# Altium schematic sheet Sheet1.SchDoc(Sheet1)
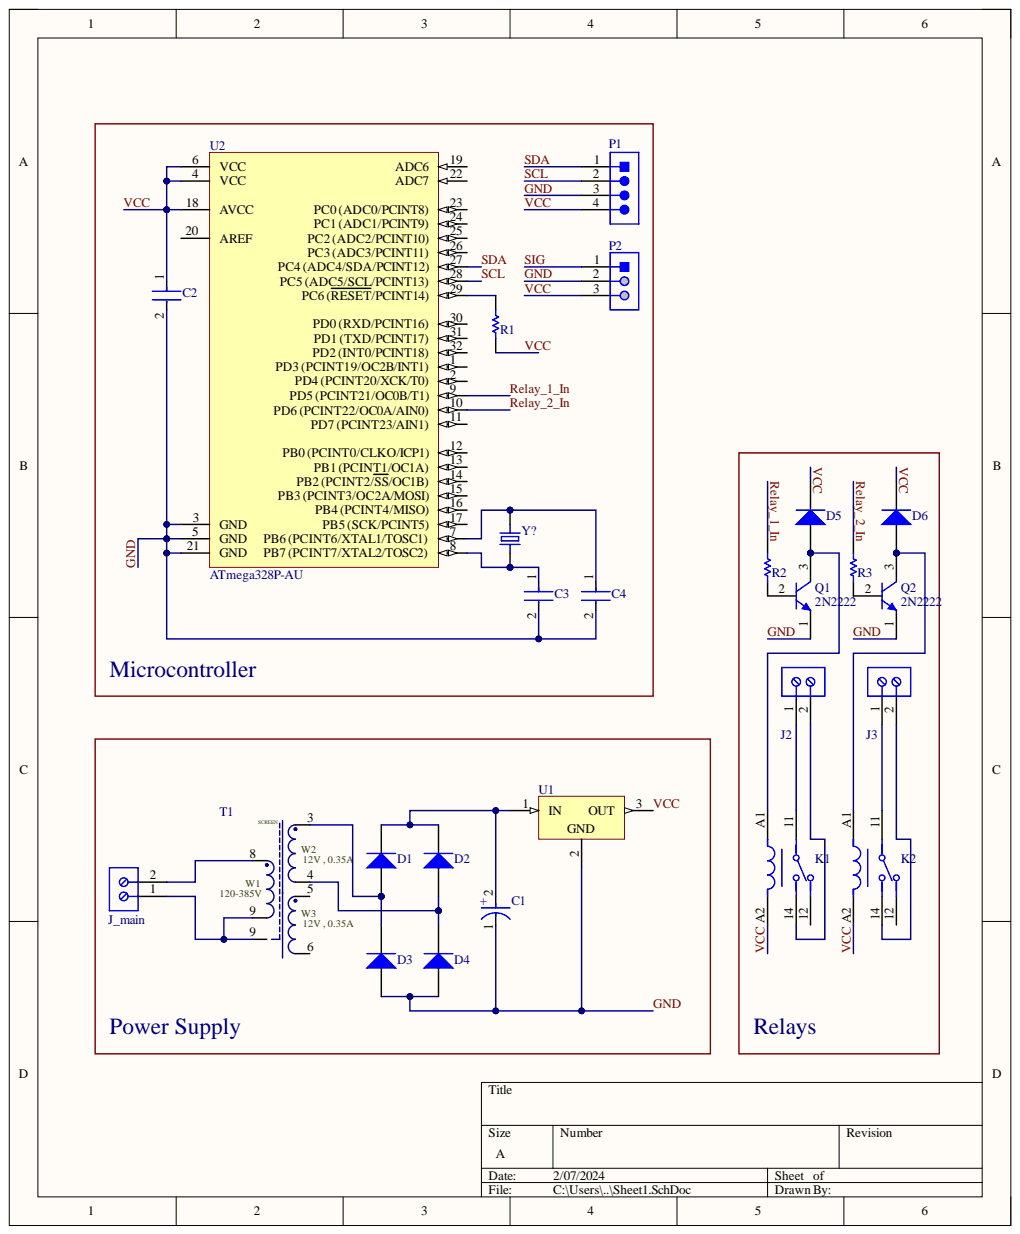
[diagram p0: Sheet1.SchDoc]

## Parts
C1  EEE-FK1E102AQ  [FP-FKH13-MFG]  (value not drawn)
C2  GRM188R60J106ME47D  [FP-GRM188-0_1-e0_2_0_55-IPC_A]  (value not drawn)
C3  RCE5C1H220J0M1H03A  [FP-RCE0K1-MFG]  (value not drawn)
C4  RCE5C1H220J0M1H03A  [FP-RCE0K1-MFG]  (value not drawn)
D1  1N4148W-7-F  [DIOD-SOD123-2_V]  (value not drawn)
D2  1N4148W-7-F  [DIOD-SOD123-2_V]  (value not drawn)
D3  1N4148W-7-F  [DIOD-SOD123-2_V]  (value not drawn)
D4  1N4148W-7-F  [DIOD-SOD123-2_V]  (value not drawn)
D5  1N4148W-7-F  [DIOD-SOD123-2_V]  (value not drawn)
D6  1N4148W-7-F  [DIOD-SOD123-2_V]  (value not drawn)
J2  1714955  [PHOE-1714955_V]  (value not drawn)
J3  1714955  [PHOE-1714955_V]  (value not drawn)
J_main  1714955  [PHOE-1714955_V]  (value not drawn)
K1  1393236-1  [FP-1393236-1-MFG]  (value not drawn)
K2  1393236-1  [FP-1393236-1-MFG]  (value not drawn)
P1  B4B-PH-K-S(LF)(SN)  [JST-B4B-PH-K-S_V]  (value not drawn)
P2  B3B-PH-K-S(LF)(SN)  [JST-B3B-PH-K-S-3_V]  (value not drawn)
Q1  2N2222  [MLTC-TO-18-3]
Q2  2N2222  [MLTC-TO-18-3]
R1  ERJ8BWFR015V  [RESC3216X70X50NL05T20]  (value not drawn)
R2  ERJ8BWFR015V  [RESC3216X70X50NL05T20]  (value not drawn)
R3  ERJ8BWFR015V  [RESC3216X70X50NL05T20]  (value not drawn)
T1  7491182012  [EE16_7_4 (E16)]  (value not drawn)
U1  LM7805CT  [FAIR-TO-220-3]  (value not drawn)
U2  ATmega328P-AU  [32A_M]
Y?  ECS-160-S-1X  [XTAL_ECS-160-S-1X]  (value not drawn)

## Nets
GND: C1.1 C2.2 C3.2 C4.2 D3.1 D4.1 P1.3 P2.2 Q1.1 Q2.1 U1.2 U2.3(GND) U2.5(GND) U2.21(GND)
Relay_1_In: R2.1 U2.9(PD5_(PCINT21/OC0B/T1))
Relay_2_In: R3.1 U2.10(PD6_(PCINT22/OC0A/AIN0))
SCL: P1.2 U2.28(PC5_(ADC5/SCL/PCINT13))
SDA: P1.1 U2.27(PC4_(ADC4/SDA/PCINT12))
SIG: P2.1
VCC: C2.1 D5.2 D6.2 K1.A2 K2.A2 P1.4 P2.3 R1.2 U1.3 U2.4(VCC) U2.6(VCC) U2.18(AVCC)
NetC1_2: C1.2 D1.2 D2.2 U1.1
NetC3_1: C3.1 U2.8 Y?.1
NetC4_1: C4.1 U2.7 Y?.2
NetD1_1: D1.1 D3.2 T1.3
NetD2_1: D2.1 D4.2 T1.4
NetD5_1: D5.1 K1.A1 Q1.3
NetD6_1: D6.1 K2.A1 Q2.3
NetJ2_1: J2.1 K1.11
NetJ2_2: J2.2 K1.14
NetJ3_1: J3.1 K2.11
NetJ3_2: J3.2 K2.14
NetJ_main_1: J_main.1 T1.9
NetJ_main_2: J_main.2 T1.8
NetQ1_2: Q1.2 R2.2
NetQ2_2: Q2.2 R3.2
NetR1_1: R1.1 U2.29(PC6_(RESET/PCINT14))

Not connected: K1 12; K2 12; T1 5 6; U2 1(PD3_(PCINT19/OC2B/INT1)) 2(PD4_(PCINT20/XCK/T0)) 11(PD7_(PCINT23/AIN1)) 12(PB0_(PCINT0/CLKO/ICP1)) 13(PB1_(PCINT1/OC1A)) 14(PB2_(PCINT2/SS/OC1B)) 15(PB3_(PCINT3/OC2A/MOSI)) 16(PB4_(PCINT4/MISO)) 17(PB5_(SCK/PCINT5)) 19(ADC6) 20(AREF) 22(ADC7) 23(PC0_(ADC0/PCINT8)) 24(PC1_(ADC1/PCINT9)) 25 26(PC3_(ADC3/PCINT11)) 30(PD0_(RXD/PCINT16)) 31(PD1_(TXD/PCINT17)) 32(PD2_(INT0/PCINT18))

## Library data of the parts
C1 = CMP-05427-002158-1: CAP ALUM 1000UF 20% 25V SMD; Capacitance: 1mF; ESR (Equivalent Series Resistance): 60mR; Life (Hours): 5000Hour; Polarity: Polar; Ripple Current: 1.1A; Case/Package: Radial; Component Kind: Standard; Construction: Cylindrical; Diameter: 12.5mm; Height: 13.5mm; Height - Seated (Max): 0.55inch; Impedance: 60mR; Lead Free: Lead Free; Length: 13.5mm; Library Name: Altium Content Vault; Max Operating Temperature: 105°C; Min Operating Temperature: -55°C; Mount: Surface Mount; Package Quantity: 200; Packaging: Tape and Reel; Radiation Hardening: No; Ratings: AEC-Q200; Reach SVHC Compliant: Yes; Ripple Current (AC): 1.1A; RoHS Compliant: Yes; Series: FK; Termination: SMD/SMT; Tolerance: 20%; Voltage: 25V; Voltage Rating: 25V; Voltage Rating (DC): 25V
C2 = CMP-2006-02792-2: Chip Multilayer Ceramic Capacitors for General Purpose, 0603, 10uF, X5R, 15%, 20%, 6.3V; Capacitance: 10uF; Case Code (Imperial): 0603; Case Code (Metric): 1608; Case/Package: 0603; Component Kind: Standard; Depth: 0.8mm; Dielectric: X5R; Height: 0.8mm; Lead Free: Lead Free; Length: 1.6mm; Library Name: Altium Content Vault; Material: Ceramic; Max Operating Temperature: 85°C; Min Operating Temperature: -55°C; Mount: Surface Mount; Package Quantity: 4000; Packaging: Tape and Reel; Radiation Hardening: No; REACH SVHC: No SVHC; Termination: SMD/SMT; Thickness: 0.035inch; Tolerance: 20%; Voltage: 6.3V; Voltage Rating: 6.3V; Voltage Rating (DC): 6.3V; Width: 0.031inch
C3 C4 = CMP-06035-004895-1: Cap Ceramic 22pF 50V C0G ±5% Radial 5mm +125°C Automotive Bulk; Lead Diameter: 0.5mm; Lead/Base Style: Formed Leads; Capacitance: 22pF; Case/Package: Radial; Component Kind: Standard; Dielectric: C0G; Height: 3.5mm; Height - Seated (Max): 0.138inch; Lead Pitch: 0.197inch; Length: 0.142inch; Library Name: Altium Content Vault; Max Operating Temperature: 125°C; Min Operating Temperature: -55°C; Mount: Through Hole; Package Quantity: 2000; Packaging: Tape and Reel; Ratings: AEC-Q200; Resistor Type: Ceramic; Termination: Radial; Tolerance: 5%; Voltage Rating: 50V; Voltage Rating (DC): 50V; Width: 0.098inch
D1-D6 = CMP-2000-04932-2: Fast Switching Diode, 100 V, 0.15 A, -65 to 150 degC, 2-Pin?SOD123, RoHS, Tape and Reel; Brand: DiodesIncorporated; Configuration: Single; If - Forward Current: 0.15A; Ir - Reverse Current: 1uA; Max Surge Current: 2A; Peak Reverse Voltage: 100V; Recovery Time: 4ns; Unit Weight: 0.000353oz; Vf - Forward Voltage: 1.25V; Component Kind: Standard; Datasheet URL: http://www.farnell.com/datasheets/1915529.pdf; Datasheet Version: Rev. 24-2, 10/2013; Factory Pack Quantity: 3000; Height: 1.25mm; Length: 2.85mm; Library Name: Altium Content Vault; Manufacturer: DiodesIncorporated; Manufacturer URL: http://www.diodes.com/; Maximum Operating Temperature: +150C; Minimum Operating Temperature: -65C; Mounting Style: SMD/SMT; Operating Temperature Range: -65Cto+150C; Package / Case: SOD-123; Package Reference: SOD123-2; Package URL: http://www.diodes.com/datasheets/ds18004.pdf; Packaging: Reel; Product: SwitchingDiodes; Product Category: Diodes-GeneralPurpose,Power,Switching; RoHS: True; Series: 1N4148; Type: SwitchingDiode; Width: 1.7mm
J2 J3 J_main = CMP-2000-05797-1: PC Terminal Block, Pitch 6.35 mm, 1 x 2 Position, Height 21.5 mm, Tail Lenght 5.1 mm, RoHS, Bulk; Manufacturer: Phoenix Contact; Component Kind: Standard; Datasheet URL: http://media.digikey.com/pdf/Data%20Sheets/Phoenix%20Contact%20PDFs/1714955.pdf; Datasheet Version: 02/2010; Library Name: Altium Content Vault; Manufacturer URL: http://www.phoenixcontact.com/; Mounting Technology: ThroughHole; Package Description: PC Terminal Block, Pitch 6.35 mm, 1 x 2 Position, Height 21.5 mm, Tail Lenght 5.1 mm; Package Reference: 1714955
K1 K2 = CMP-03395-000054-1: SNR Series 6 A SPDT (1 Form A) 5 VDC PCB Mount General Purpose Power Relay; Manufacturer: TE; Coil Power: 170mW; Coil Resistance: 147Ω; Coil Type: Non-Latching; Coil Voltage: 5V; Current Rating: 6A; Number Of Contacts: 1; Standoff Height: 0.5mm; Ambient Temperature Range High: +85°C; Ambient Temperature Range Low: -40°C; Automotive: No; Category: Relay; Component Kind: Standard; ComponentLink2Description: Datasheet; ComponentLink2URL: https://www.te.com/usa-en/product-1393236-1.html?te_bu=Cor&te_type=other&te_campaign=oct_glo_cor-oct-global-oth-prtnr-fy19-oct-octo-buy-bom_sma-298_2&elqCampaignId=35216; Device Class L1: Electromechanical; Device Class L2: Relays; Device Class L3: Power Relays; Digikey Description: RELAY GENERAL PURPOSE SPDT 6A 5V; Height: 15mm; Lead Free: Yes; Library Name: Altium Content Vault; Manufacturer Part Number: 1393236-1; Mouser Description: General Purpose Relays RELAY PWR SPDT; Octopart: https://octopart.com/1393236-1-te+connectivity-40317174; Package: 1393236-1; RoHS: TRUE; Throw Configuration: SPDT
P1 = CMP-2000-05165-1: Male Header, Pitch 2 mm, 1 x 4 Position, Height 6 mm, Tail Length 3.4 mm, -25 to 85 degC, RoHS, Bulk; Manufacturer: JST; No of Positions: 4; Pitch: 2mm; Style/Orientation: StraightHeader/Plug; Component Kind: Standard; Datasheet URL: http://datasheet.octopart.com/B4B-PH-K-S%28LF%29%28SN%29-JST-datasheet-10558886.pdf; Datasheet Version: Apr-09; Library Name: Altium Content Vault; Manufacturer URL: http://www.jst.com/home2.html; Termination: Crimp; Type: ShroudedHeader
P2 = CMP-2000-05164-1: Male Header, Pitch 2 mm, 1 x 3 Position, Height 6 mm, Tail Length 3.4 mm, -25 to 85 degC, RoHS, Bulk; Manufacturer: JST; No of Positions: 3; Pitch: 2mm; Style/Orientation: StraightHeader/Plug; Component Kind: Standard; Datasheet URL: https://datasheet.ciiva.com/11746/0900766b80826550-11746939.pdf; Datasheet Version: Oct-06; Library Name: Altium Content Vault; Manufacturer URL: http://www.jst.com/home2.html; Termination: Crimp; Type: ShroudedHeader
Q1 Q2 = CMP-2000-05237-1: Low Power Bipolar NPN Transistor, 30 V, -65 to 200 degC, 3-Pin TO-18, RoHS, Bulk; Manufacturer: Multicomp; Collector Emitter Voltage V(br)ceo: 30V; Collector Emitter Voltage Vces: 400mV; Continuous Collector Current Ic Max: 800mA; Current Ic @ Vce Sat: 150mA; DC Current Gain hFE: 100; Full Power Rating Temperature: 25degC; Gain Bandwidth ft Min: 250MHz; No. of Pins: 3; No. of Transistors: 1; Pin Configuration: a; Power Dissipation Pd: 500mW; SVHC: NoSVHC(19-Dec-2012); Voltage Vcbo: 60V; Component Kind: Standard; Current Ic Continuous a Max: 800mA; Current Ic hFE: 150mA; Datasheet URL: http://www.farnell.com/datasheets/1662272.pdf; Datasheet Version: Rev. 1.1, 05/2012; DC Collector Current: 800mA; Gain Bandwidth ft Typ: 250MHz; Hfe Min: 100; Library Name: Altium Content Vault; Manufacturer URL: http://uk.farnell.com/b/multicomp; Package / Case: TO-18; Package Description: 3-Pin Transistor Outline Package (TO-18), Dia 5.54 mm, Pitch Pitch 1.485mm; Package Reference: TO-18-3; Power Dissipation Ptot Max: 500mW; Transistor Case Style: TO-18; Transistor Polarity: NPN
R1-R3 = CMP-2003-00767-1: Manufacturer: Panasonic; Component Kind: Standard; Library Name: Altium Content Vault; Part Number: ERJ8BWFR015V
T1 = CMP-1471-00001-1: Off-Line Transformer WE-UNIT , L=0.9mH; Manufacturer: Wuerth Elektronik; Inductance: 0.9mH; Input Voltage (AC): 85-265; Output Current (A): 0.6; Output Voltage (DC): 12; Turns Ratio: "9.5","1:1"; Component Kind: Standard; ComponentLink1Description: Manufacturer URL; ComponentLink1URL: http://www.we-online.com; ComponentLink2Description: Datasheet; ComponentLink2URL: http://www.we-online.de/katalog/media/pdf/7491182012.pdf; Library Name: Altium Content Vault; PackageReference: EE16_7_4 (E16); PartNumber: 7491182012
U1 = CMP-2000-04938-1: Positive Voltage Regulator, 5 V, 1 A, -40 to 125 degC, 3-Pin TO-220, RoHS, Tube; Manufacturer: FairchildSemiconductor; Accuracy: ±4%; Line Regulation: 100mV; Maximum Input Voltage: 35V; Maximum Output Current: 2.2(Typ)A; Maximum Quiescent Current: 8mA; Number of Outputs: 1; Output Type: Fixed; Output Voltage: 5V; Rad Hard: No; Typical Dropout Voltage @ Current: 2@1AV; Category: LinearRegulator; Component Kind: Standard; Datasheet URL: https://datasheet.ciiva.com/26904/lm7805ct-26904988.pdf; Datasheet Version: Aug-12; Library Name: Altium Content Vault; Load Regulation: 100mV; Manufacturer URL: https://www.fairchildsemi.com/; Mounting: ThroughHole; Operating Temperature: -40to125degC; Package: 3TO-220AB; Package Description: 3-Pin Through Hole Device, Body 10 x 4.5 mm, Pitch 2.54 mm; Package Reference: TO-220; Package URL: https://www.fairchildsemi.com/package-drawings/TO/TO220B03.pdf; Packaging: Rail/Tube; Polarity: Positive; Type: Linear
U2 = CMP-0095-00269-2: 8-bit AVR Microcontroller, 32KB Flash, 1KB EEPROM, 2KB SRAM, 32-pin TQFP, Industrial Grade (-40°C to 85°C); Code_JEDEC: MS-026-ABA; Component Kind: Standard; ComponentLink1Description: Manufacturer URL; ComponentLink1URL: http://www.atmel.com/; ComponentLink2Description: ATmega48A/48PA/88A/88PA/168A/168PA/328/328P Datasheet; ComponentLink2URL: http://www.atmel.com/dyn/resources/prod_documents/doc8271.pdf; ComponentLink3Description: ATmega48A/48PA/88A/88PA/168A/168PA/328/328P Summary; ComponentLink3URL: http://www.atmel.com/dyn/resources/prod_documents/8271S.pdf; DatasheetVersion: revD, May-2011; Library Name: Altium Content Vault; PackageDescription: 32-Lead, 7x7x1.0 mm Body, 0.8mm Lead Pitch, Thin Profile Plastic Quad Flat Package (TQFP); PackageReference: 32A; PackageVersion: revC, Oct-2010; RevisionNote: DatasheetVersion & PackageVersion updated. URLs added.
Y? = ECS-160-S-1X: 16MHz Â±30ppm Crystal Series 20 Ohms HC-49/U; MF: ECS Inc.; Availability: Unavailable; Component Kind: Standard; Library Name: ECS-160-S-1X.IntLib; MP: ECS-160-S-1X; Package: HC49/U ECS International; Price: None; SnapEDA_Link: https://www.snapeda.com/parts/ECS-160-S-1X/ECS%20Inc./view-part/2458894/?ref=snap
